# Altium schematic sheet MCU_STM32F407VGT.SchDoc
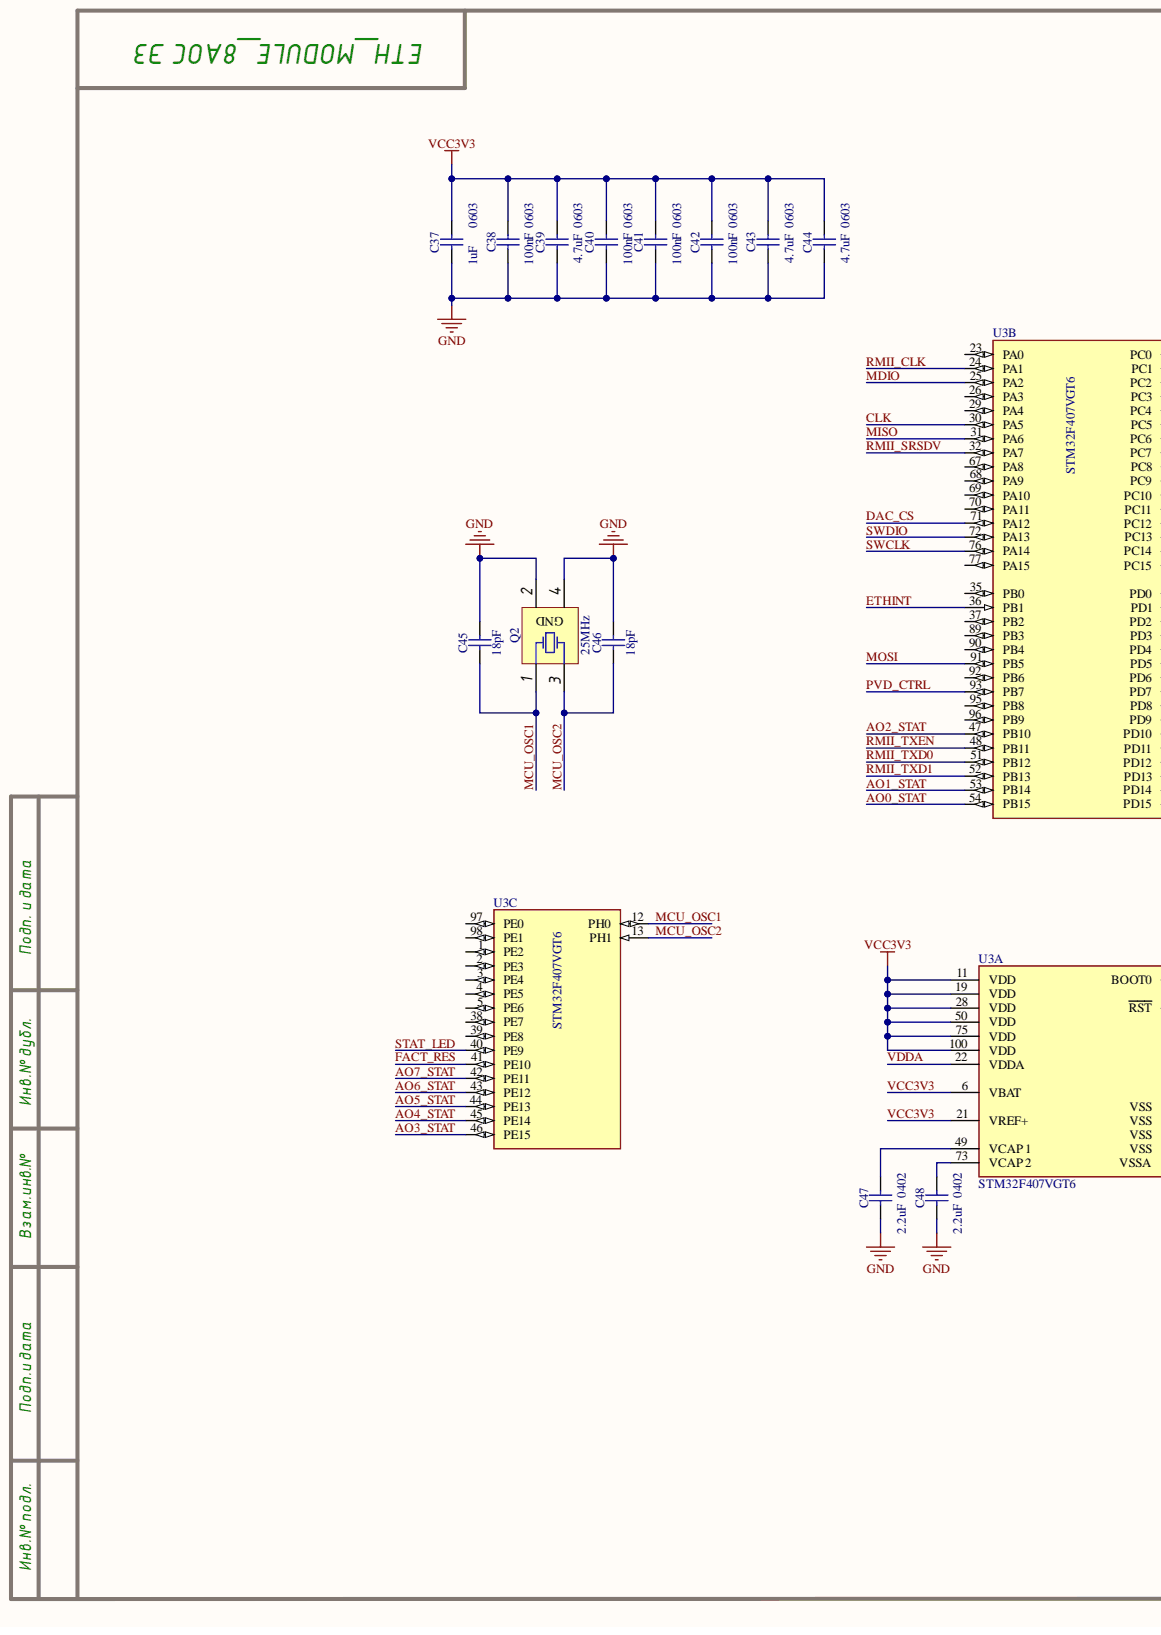
[diagram p0: MCU_STM32F407VGT.SchDoc, left]
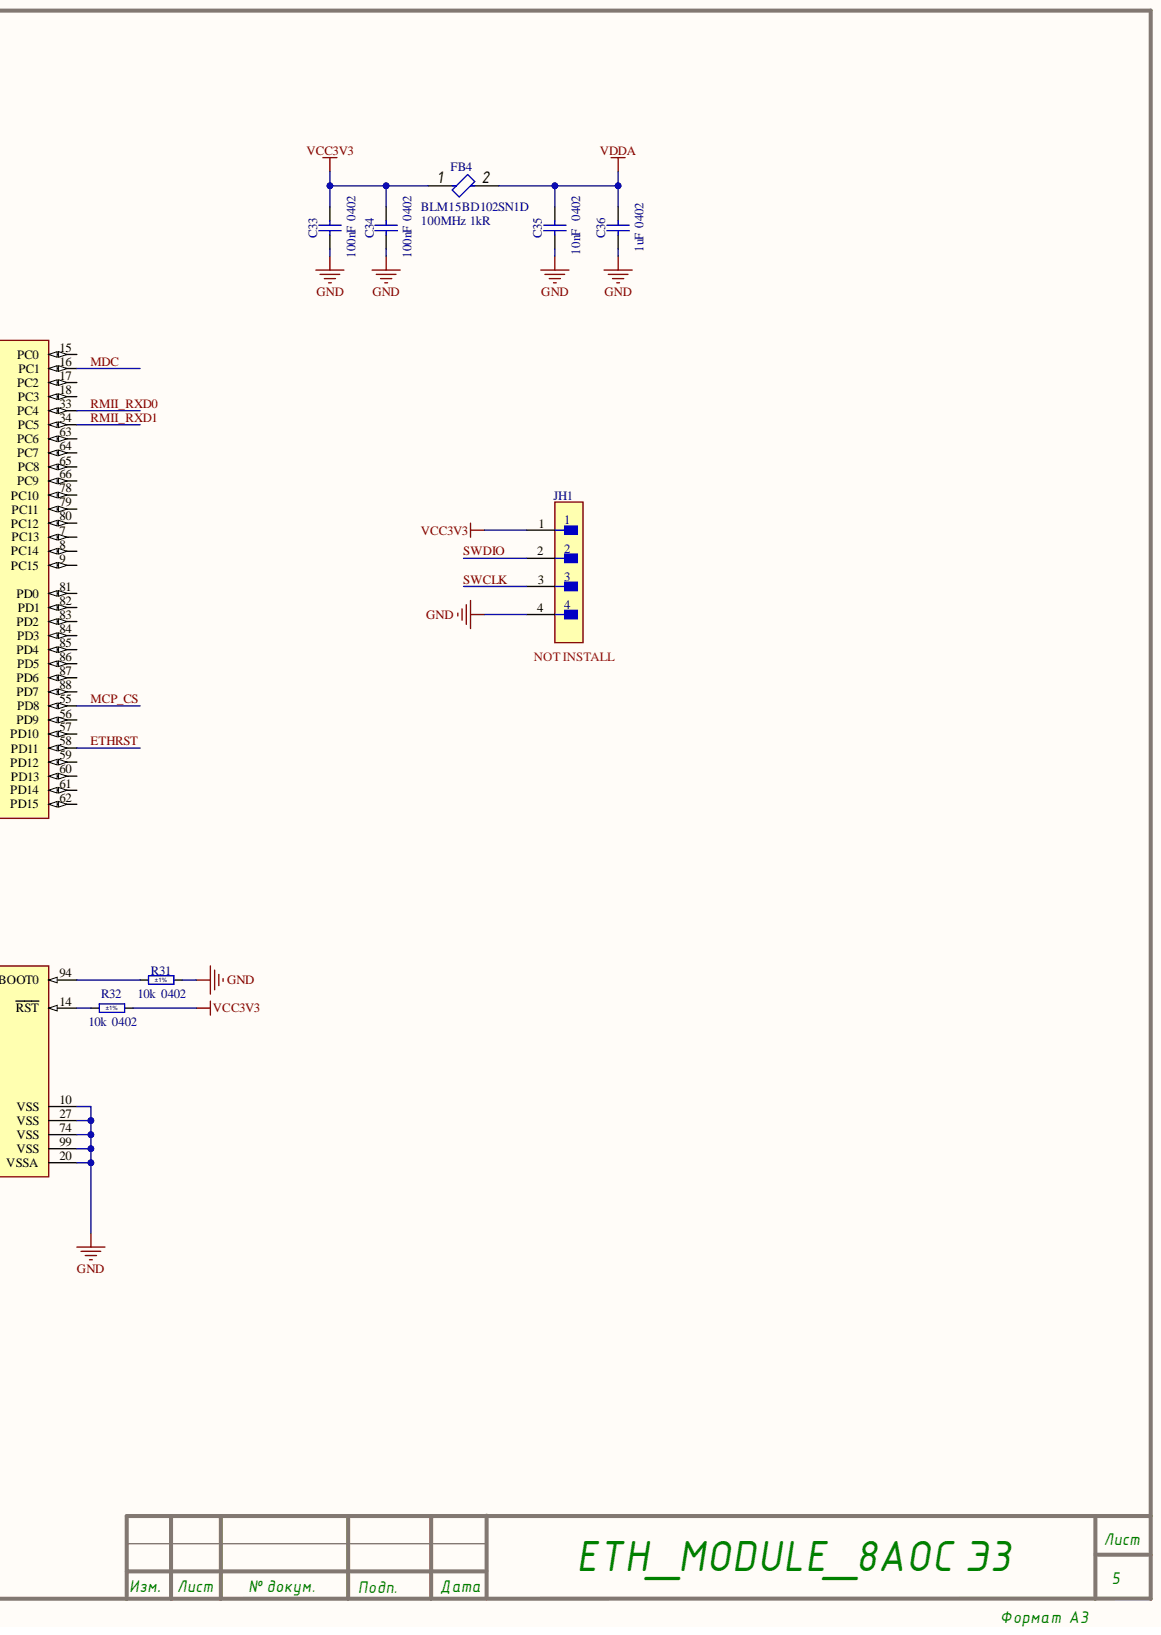
[diagram p1: MCU_STM32F407VGT.SchDoc, right]

## Parts
C33  100nF  [CAPC1005X55N]
C34  100nF  Case: 0402  [CAPC1005X55N]
C35  10nF  Case: 0402  [CAPC1005X55N]
C36  1uF  Case: 0402  [CAPC1005X55N]
C37  1uF  Case: 0603  [CAPC1608X87N]
C38  100nF  Case: 0603  [CAPC1608X87N]
C39  4.7uF  Case: 0603  [CAPC1709X95N]
C40  100nF  Case: 0603  [CAPC1608X87N]
C41  100nF  Case: 0603  [CAPC1608X87N]
C42  100nF  Case: 0603  [CAPC1608X87N]
C43  4.7uF  Case: 0603  [CAPC1709X95N]
C44  4.7uF  Case: 0603  [CAPC1709X95N]
C45  18pF  [CAPC1608X87N]
C46  18pF  [CAPC1608X87N]
C47  2.2uF  Case: 0402  [CAPC1005X55N]
C48  2.2uF  Case: 0402  [CAPC1005X55N]
FB4  BLM15BD102SN1D  Case Code (Imperial): 0402  Frequency: 100MHz  Impedance: 1kR  [FP-BLM15-0_05-IPC_B]
JH1  NOT INSTALL  [FP-TSW-104-23-T-S-MFG]
Q2  25MHz  [XTAL_EPSON_TSX-3225]
R31  10k  Case: 0402  Tolerance: ±1%  [RESC1005X40N]
R32  10k  Case: 0402  Tolerance: ±1%  [RESC1005X40N]
U3  STM32F407VGT6  [FP-LQFP100-1L-MFG]  (parts A,B,C)

## Nets
GND: C33.1 C34.1 C35.1 C36.1 C37.1 C38.1 C39.1 C40.1 C41.1 C42.1 C43.1 C44.1 C45.2 C46.2 C47.1 C48.1 JH1.4 Q2.2 Q2.4 R31.2 U3.10(VSS) U3.20(VSSA) U3.27(VSS) U3.74(VSS) U3.99(VSS)
AO0_STAT: U3.54(PB15)
AO1_STAT: U3.53(PB14)
AO2_STAT: U3.47(PB10)
AO3_STAT: U3.46(PE15)
AO4_STAT: U3.45(PE14)
AO5_STAT: U3.44(PE13)
AO6_STAT: U3.43(PE12)
AO7_STAT: U3.42(PE11)
CLK: U3.30(PA5)
DAC_CS: U3.71(PA12)
ETHINT: U3.36(PB1)
ETHRST: U3.58(PD11)
FACT_RES: U3.41(PE10)
MCP_CS: U3.55(PD8)
MCU_OSC1: C45.1 Q2.1 U3.12(PH0)
MCU_OSC2: C46.1 Q2.3 U3.13(PH1)
MDC: U3.16(PC1)
MDIO: U3.25(PA2)
MISO: U3.31(PA6)
MOSI: U3.91(PB5)
PVD_CTRL: U3.93(PB7)
RMII_CLK: U3.24(PA1)
RMII_RXD0: U3.33(PC4)
RMII_RXD1: U3.34(PC5)
RMII_SRSDV: U3.32(PA7)
RMII_TXD0: U3.51(PB12)
RMII_TXD1: U3.52(PB13)
RMII_TXEN: U3.48(PB11)
STAT_LED: U3.40(PE9)
SWCLK: JH1.3 U3.76(PA14)
SWDIO: JH1.2 U3.72(PA13)
VCC3V3: C33.2 C34.2 C37.2 C38.2 C39.2 C40.2 C41.2 C42.2 C43.2 C44.2 FB4.1 JH1.1 R32.2 U3.6(VBAT) U3.11(VDD) U3.19(VDD) U3.21(VREF+) U3.28(VDD) U3.50(VDD) U3.75(VDD) U3.100(VDD)
VDDA: C35.2 C36.2 FB4.2 U3.22(VDDA)
NetC47_2: C47.2 U3.49(VCAP_1)
NetC48_2: C48.2 U3.73(VCAP_2)
NetR31_1: R31.1 U3.94(BOOT0)
NetR32_1: R32.1 U3.14(RST)

Not connected: U3 1(PE2) 2(PE3) 3(PE4) 4(PE5) 5(PE6) 7(PC13) 8(PC14) 9(PC15) 15(PC0) 17(PC2) 18(PC3) 23(PA0) 26(PA3) 29(PA4) 35(PB0) 37(PB2) 38(PE7) 39(PE8) 56(PD9) 57(PD10) 59(PD12) 60(PD13) 61(PD14) 62(PD15) 63(PC6) 64(PC7) 65(PC8) 66(PC9) 67(PA8) 68(PA9) 69(PA10) 70(PA11) 77(PA15) 78(PC10) 79(PC11) 80(PC12) 81(PD0) 82(PD1) 83(PD2) 84(PD3) 85(PD4) 86(PD5) 87(PD6) 88(PD7) 89(PB3) 90(PB4) 92(PB6) 95(PB8) 96(PB9) 97(PE0) 98(PE1)

## Library data of the parts
C33 C34 = CC0402_100NF_6.3V_10%_X7R: Non-polarised capacitor; Manufacturer: GENERIC; Manufacturer1 Part Number: GRM155R70J104KA01D; Part Description: SMD Multilayer Chip Ceramic Capacitor; Database Table Name: Capacitors SMD; TC: X7R; Tolerance: ±10%; Voltage: 6.3V; Component Kind: Standard; Component Type: Standard; CreateDate: 02.11.2015; HelpURL: \\cern.ch\dfs\Applications\Altium\Datasheets\CC0402_X7R_MURATA_GRM.pdf; LatestRevisionDate: 02.11.2015; Library Name: Capacitors.DbLib; Manufacturer1 ComponentHeight: 0.55mm; Manufacturer1 Example: MURATA; Manufacturer Part Number: CC0402_100NF_6.3V_10%_X7R; Mounted: Yes; Part Number: CC0402_100NF_6.3V_10%_X7R; Pin Count: 2; Sense: No; SMD: Yes; Socket: No; Status: Not Recommended; Value: 100nF; Author: CERN DEM BC
  C33: Case: 0402
C35 = CC0402_10NF_16V_10%_X7R: Non-polarised capacitor; Manufacturer: GENERIC; Manufacturer1 Part Number: 223878715636; Part Description: SMD Multilayer Chip Ceramic Capacitor; Database Table Name: Capacitors SMD; TC: X7R; Tolerance: ±10%; Voltage: 16V; Component Kind: Standard; Component Type: Standard; CreateDate: 03.12.2007; HelpURL: \\cern.ch\dfs\Applications\Altium\Datasheets\CC0402_X7R_PHYCOMP.pdf; LatestRevisionDate: 03.12.2007; Library Name: Capacitors.DbLib; Manufacturer1 ComponentHeight: 0.55mm; Manufacturer1 Example: PHYCOMP; Manufacturer Part Number: CC0402_10NF_16V_10%_X7R; Mounted: Yes; Part Number: CC0402_10NF_16V_10%_X7R; Pin Count: 2; Sense: No; SMD: Yes; Socket: No; Status: Not Recommended; Value: 10nF; Author: CERN DEM JLC
C36 = CC0402_1UF_16V_10%_X5R: Non-polarised capacitor; Manufacturer: GENERIC; Manufacturer1 Part Number: C1005X5R1C105KT; Part Description: SMD Multilayer Chip Ceramic Capacitor; Database Table Name: Capacitors SMD; TC: X5R; Tolerance: ±10%; Voltage: 16V; Component Kind: Standard; Component Type: Standard; CreateDate: 25.10.2012; HelpURL: \\cern.ch\dfs\Applications\Altium\Datasheets\CC0402_X5R_TDK_C.pdf; LatestRevisionDate: 25.10.2012; Library Name: Capacitors.DbLib; Manufacturer1 ComponentHeight: 0.55mm; Manufacturer1 Example: TDK; Manufacturer Part Number: CC0402_1UF_16V_10%_X5R; Mounted: Yes; Part Number: CC0402_1UF_16V_10%_X5R; Pin Count: 2; Sense: No; SMD: Yes; Socket: No; Status: Preferred; Value: 1uF; Author: CERN DEM JLC
C37 = CC0603_1UF_16V_10%_X7R: Non-polarised capacitor; Manufacturer: GENERIC; Manufacturer1 Part Number: 223878615663; Part Description: SMD Multilayer Chip Ceramic Capacitor; Database Table Name: Capacitors SMD; TC: X7R; Tolerance: ±10%; Voltage: 16V; Component Kind: Standard; Component Type: Standard; CreateDate: 03.12.2007; HelpURL: \\cern.ch\dfs\Applications\Altium\Datasheets\CC0603_X7R_PHYCOMP.pdf; LatestRevisionDate: 17.04.2015; Library Name: Capacitors.DbLib; Manufacturer1 ComponentHeight: 0.9mm; Manufacturer1 Example: YAGEO PHYCOMP; Manufacturer Part Number: CC0603_1UF_16V_10%_X7R; Mounted: Yes; Part Number: CC0603_1UF_16V_10%_X7R; Pin Count: 2; Sense: No; SMD: Yes; Socket: No; Status: None; Value: 1uF; Author: CERN DEM JLC
C38 C40-C42 = CC0603_100NF_50V_10%_X7R: Non-polarised capacitor; Manufacturer: GENERIC; Manufacturer1 Part Number: 223858615649; Part Description: SMD Multilayer Chip Ceramic Capacitor; Database Table Name: Capacitors SMD; TC: X7R; Tolerance: ±10%; Voltage: 50V; Component Kind: Standard; Component Type: Standard; CreateDate: 03.12.2007; HelpURL: \\cern.ch\dfs\Applications\Altium\Datasheets\CC0603_X7R_PHYCOMP.pdf; LatestRevisionDate: 03.12.2007; Library Name: Capacitors.DbLib; Manufacturer1 ComponentHeight: 0.87mm; Manufacturer1 Example: PHYCOMP; Manufacturer Part Number: CC0603_100NF_50V_10%_X7R; Mounted: Yes; Part Number: CC0603_100NF_50V_10%_X7R; Pin Count: 2; Sense: No; SMD: Yes; Socket: No; Status: Preferred; Value: 100nF; Author: CERN DEM JLC
C39 C43 C44 = CC0603_4.7UF_16V_10%_X5R: Non-polarised capacitor; Manufacturer: GENERIC; Manufacturer1 Part Number: EMK107ABJ475KA-T; Part Description: SMD Multilayer Chip Ceramic Capacitor; Database Table Name: Capacitors SMD; TC: X5R; Tolerance: ±10%; Voltage: 16V; Component Kind: Standard; Component Type: Standard; CreateDate: 16.12.2013; HelpURL: \\cern.ch\dfs\Applications\Altium\Datasheets\CC0603_X7R_TAIYO-YUDEN_HIGH-VALUE.pdf; LatestRevisionDate: 16.09.2014; Library Name: Capacitors.DbLib; Manufacturer1 ComponentHeight: 0.95mm; Manufacturer1 Example: TAIYO YUDEN; Manufacturer Part Number: CC0603_4.7UF_16V_10%_X5R; Mounted: Yes; Part Number: CC0603_4.7UF_16V_10%_X5R; Pin Count: 2; Sense: No; SMD: Yes; Socket: No; Status: None; Value: 4.7uF; Author: CERN DEM JLC
C45 C46 = CC0603_18PF_50V_5%_NP0: Non-polarised capacitor; Manufacturer: GENERIC; Manufacturer1 Part Number: 223886715189; Part Description: SMD Multilayer Chip Ceramic Capacitor; Database Table Name: Capacitors SMD; TC: C0G/NP0; Tolerance: ±5%; Voltage: 50V; Case: 0603; Component Kind: Standard; Component Type: Standard; CreateDate: 03.12.2007; HelpURL: \\cern.ch\dfs\Applications\Altium\Datasheets\CC0603_NP0_PHYCOMP.pdf; LatestRevisionDate: 03.12.2007; Library Name: Capacitors.DbLib; Manufacturer1 ComponentHeight: 0.87mm; Manufacturer1 Example: PHYCOMP; Manufacturer Part Number: CC0603_18PF_50V_5%_NP0; Mounted: Yes; Part Number: CC0603_18PF_50V_5%_NP0; Pin Count: 2; Sense: No; SMD: Yes; Socket: No; Status: Preferred; Value: 18pF; Author: CERN DEM JLC
C47 C48 = CC0402_2.2UF_6.3V_10%_X5R: Non-polarised capacitor; Manufacturer: GENERIC; Manufacturer1 Part Number: C1005X5R0J225K050; Part Description: SMD Multilayer Chip Ceramic Capacitor; Database Table Name: Capacitors SMD; TC: X5R; Tolerance: ±10%; Voltage: 6.3V; Component Kind: Standard; Component Type: Standard; CreateDate: 22.09.2015; HelpURL: \\cern.ch\dfs\Applications\Altium\Datasheets\CC0402_X5R_TDK_C.pdf; LatestRevisionDate: 22.09.2015; Library Name: Capacitors.DbLib; Manufacturer1 ComponentHeight: 0.55mm; Manufacturer1 Example: TDK; Manufacturer Part Number: CC0402_2.2UF_6.3V_10%_X5R; Mounted: Yes; Part Number: CC0402_2.2UF_6.3V_10%_X5R; Pin Count: 2; Sense: No; SMD: Yes; Socket: No; Status: Preferred; Value: 2.2uF; Author: CERN DEM JMW
FB4 = CMP-0686-00192-4: Chip Ferrite Bead, 0402, 1000Ω @ 100MHz, 0.9Ω, 25%, 200mA; DC Resistance (DCR): 900mR; Material: Steel; Number of Circuits: 1; Shielding: Unshielded; Case Code (Metric): 1005; Case/Package: 0402; Component Kind: Standard; Core Material: Ferrite; Current Rating: 200mA; DC Resistance-Max: 900mR; Depth: 0.5mm; Height: 0.5mm; Lead Free: Lead Free; Length: 1mm; Library Name: Altium Content Vault; Max Current Rating: 200mA; Max DC Current: 200mA; Max Operating Temperature: 125°C; Min Operating Temperature: -55°C; Number of Lines: 1; Number of Pins: 2; Package Quantity: 1; Packaging: Tape and Reel; Radiation Hardening: No; REACH SVHC: No SVHC; Resistance: 900mR; Series: BLM15B; Termination: Flat; Test Frequency: 100MHz; Tolerance: 25%; Width: 0.5mm
JH1 = CMP-02766-001388-1: CONN HEADER VERT 4POS 2.54MM; Connector Type: Male Pin; Gender: Male; Max Current Rating: 7.6A; Max Voltage Rating (AC): 550V; Number of Contacts: 4; Color: Black; Component Kind: Standard; Contact Material: Bronze; Contact Mating Length: 0.23inch; Contact Plating: Tin; Contact Type: Male Pin; Depth: 2.54mm; Housing Color: Black; Housing Material: Polyester; Lead Free: Lead Free; Length: 10.16mm; Library Name: Altium Content Vault; Max Operating Temperature: 105°C; Min Operating Temperature: -55°C; Mount: Through Hole; Number of Positions: 4; Number of Rows: 1; Orientation: Straight; Package Quantity: 1; Packaging: Bulk; Pitch: 2.54mm; Radiation Hardening: No; REACH SVHC: No SVHC; RoHS Compliant: Yes; Series: TSW; Termination: Solder; Width: 2.54mm
Q2 = XTAL_25MHZ_EPSON_TSX-3225 25.0000MF10Z-AC: Crystal 4 pins 1=XTAL Control 2=GND3=XTAL 4=GND; Manufacturer: EPSON; Part Description: Tolerance: ±10ppm,  Stability:±10ppm, -20°C to 75°C, 9pF, Surface Mount Quartz Crystal, TX-3225 Series; Bonding: No; Database Table Name: Crystals & Oscillators; PressFit: No; Component Kind: Standard; Component Type: Standard; ComponentHeight: 0.6mm; ComponentLink1Description: Ordering Informations; ComponentLink1URL: \\cern.ch\dfs\Applications\Altium\Datasheets\XTAL_EPSON_TSX-3225_Ordering-Informations.pdf; CreateDate: 03.04.2012; HelpURL: \\cern.ch\dfs\Applications\Altium\Datasheets\XTAL_EPSON_TSX-3225.pdf; LatestRevisionDate: 06.10.2016; Library Name: ICs And Semiconductors.DbLib; Manufacturer Part Number: TSX-3225 25.0000MF10Z-AC; Mounted: Yes; PackageDescription: Crystal, Corner Concave; 4 Pin, 2.50mm L X 3.20mm W X 0.6mm H, TSX-3225 Serie; Part Number: XTAL_25MHZ_EPSON_TSX-3225 25.0000MF10Z-AC; Pin Count: 4; Sense: No; SMD: Yes; Socket: No; Value: 25MHz; Author: CERN DEM JLC
R31 R32 = R0402_10K_1%_0.0625W_100PPM: Resistor - 1%; Manufacturer: GENERIC; Manufacturer1 Part Number: 232270671003L; Part Description: General Purpose Thick Film Chip Resistor; Database Table Name: Resistors SMD; PressFit: No; TC: ±100ppm/°C; Component Kind: Standard; Component Type: Standard; CreateDate: 03.12.2007; HelpURL: \\cern.ch\dfs\Applications\Altium\Datasheets\R0402_Phycomp_RC0402.pdf; LatestRevisionDate: 17.10.2012; Library Name: Resistors.DbLib; Manufacturer1 ComponentHeight: 0.4mm; Manufacturer1 Example: YAGEO PHYCOMP; Manufacturer Part Number: R0402_10K_1%_0.0625W_100PPM; Mounted: Yes; Part Number: R0402_10K_1%_0.0625W_100PPM; Pin Count: 2; Power: 0.0625W; Sense: No; SMD: Yes; Socket: No; Status: Not Recommended; Value: 10k; Author: CERN DEM JLC
U3 = CMP-0243-00017-5: Manufacturer Package Identifier: 1L_ME_V5; NexarPartId: 20570110; Address Bus Width: 32b; Core Architecture: ARM Cortex-M4; Flash Memory Size: 1MB; Frequency: 168MHz; Interface: CAN, Ethernet, I2C, I2S, IrDA, LIN, SPI, UART, USART, USB; Max Junction Temperature (Tj): 125°C; Max Supply Voltage: 3.6V; Memory Type: FLASH; Min Supply Voltage: 1.8V; Nominal Supply Current: 1.7µA; Number of A/D Converters: 3; Number of ADC Channels: 16; Number of D/A Converters: 2; Number of Ethernet Channels: 1; Number of I/Os: 82; Number of Timers/Counters: 14; Number of USART Channels: 4; Oscillator Type: Internal; Peripherals: Brown-out Detect/Reset, DMA, I2C, LCD, POR, PWM, WDT; RAM Size: 192kB; Watchdog Timer: Yes; Ambient Temperature Range High: 85°C; Case/Package: LQFP; Component Kind: Standard; Data Bus Width: 32b; Height: 1.45mm; Lead Free: Lead Free; Length: 14.2mm; Library Name: Altium Content Vault; Lifecycle Status: Production; Max Frequency: 168MHz; Max Operating Temperature: 85°C; Memory Size: 1MB; Min Operating Temperature: -40°C; Number of Channels: 16; Number of I2C Channels: 3; Number of Pins: 100; Number of Programmable I/O: 82; Number of PWM Channels: 2; Number of SPI Channels: 3; Number of UART Channels: 2; Number of USB Channels: 1; Radiation Hardening: No; REACH SVHC: No SVHC; RoHS: Compliant; Schedule B: 8542310000, 8542310000; Width: 14.2mm
